# Altium schematic sheet Power.SchDoc
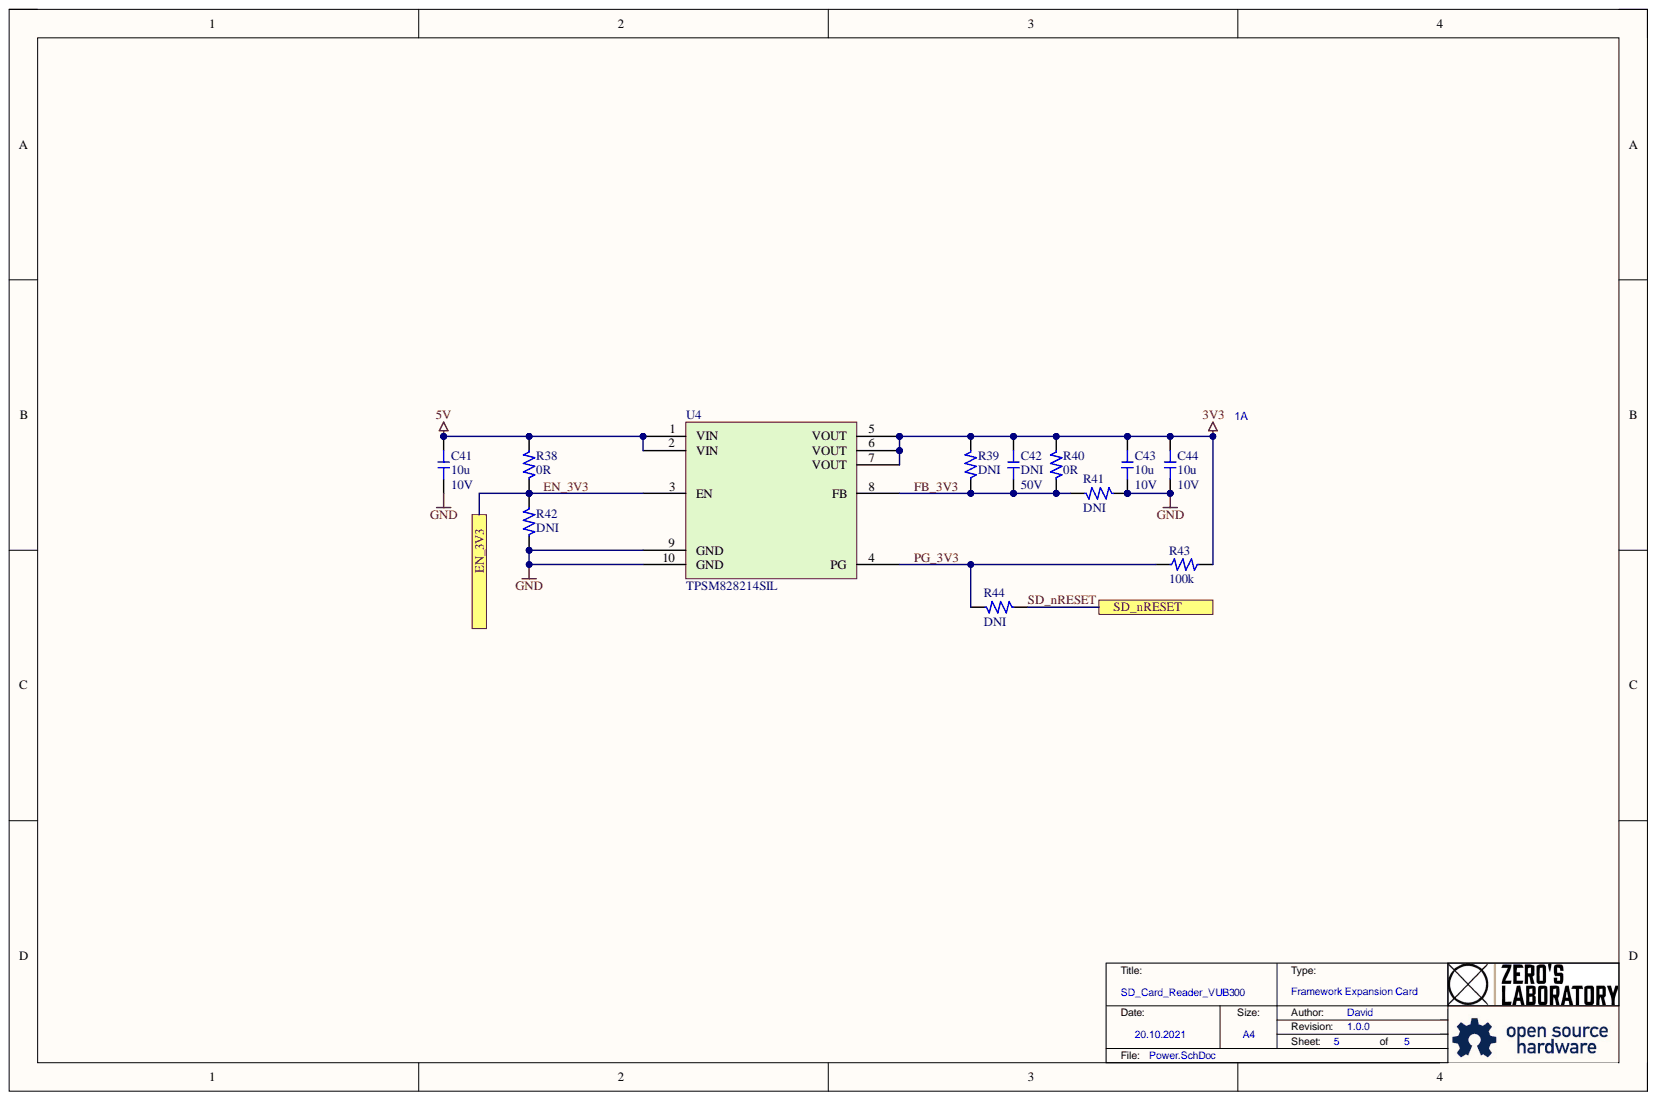
[diagram p0: Power.SchDoc]

## Parts
C41  10u  Voltage: 10V  [[1206]_CAPC320X160X160L50]
C42  DNI  Voltage: 50V  [[0402]_CAPC100X50X50L25]
C43  10u  Voltage: 10V  [[1206]_CAPC320X160X160L50]
C44  10u  Voltage: 10V  [[1206]_CAPC320X160X160L50]
R38  0R  [[0402]_RESC100X50X35L25]
R39  DNI  [[0402]_RESC100X50X35L25]
R40  0R  Voltage: 50V  [[0402]_RESC100X50X35L25]
R41  DNI  [[0402]_RESC100X50X35L25]
R42  DNI  [[0402]_RESC100X50X35L25]
R43  100k  [[0402]_RESC100X50X35L25]
R44  DNI  [[0402]_RESC100X50X35L25]
U4  TPSM828214SIL

## Ports
EN_3V3, SD_nRESET

## Nets
3V3: C42.2 C43.1 C44.1 R39.1 R40.1 R43.1 U4.5(VOUT) U4.6(VOUT) U4.7(VOUT)
5V: C41.1 R38.1 U4.1(VIN) U4.2(VIN)
GND: C41.2 C43.2 C44.2 R41.1 R42.2 U4.9(GND) U4.10(GND)
EN_3V3: R38.2 R42.1 U4.3(EN) ; port EN_3V3
FB_3V3: C42.1 R39.2 R40.2 R41.2 U4.8(FB)
PG_3V3: R43.2 R44.1 U4.4(PG)
SD_nRESET: R44.2 ; port SD_nRESET

## Library data of the parts
C41 C43 C44 = Capacitor: Manufacturer: CAL-CHIP ELECTRONICS; MPN: GMC31X7R106K10NT; Capacitance: 10uF; Dielectric: X7R; Tolerance: ±10%; Type: Ceramic; Component Kind: Standard; Description: Capacitor; Library Name: Capacitor.SchLib; Operating Temperature [°C]: -55 to +125; Package: 1206[3216]; Package Type: SMD; Size: 2.00mm x 1.25mm; Value: 10u
C42 = Capacitor: Capacitance: 120pF; Dielectric: X7R; Tolerance: ±10%; Type: Ceramic; Value: 120p; Component Kind: Standard; Description: Capacitor; Library Name: Capacitor.SchLib; Operating Temperature [°C]: -55 to +125; Package: 0402[1005]; Package Type: SMD; Size: 1.00mm x 0.50mm
R38 = Resistor: Rated Power: 62.5mW; Resistance: 0Ω; Tolerance: ±1%; Voltage: 50V; Component Kind: Standard; Description: Resistor; Library Name: Resistor.SchLib; Operating Temperature [°C]: -55 to +155; Package: 0402[1005]; Package Type: SMD; Size: 1.00mm x 0.50mm; Value: 0R
R39 = Resistor: Rated Power: 62.5mW; Resistance: 453kΩ; Tolerance: ±1%; Value: 453k; Voltage: 50V; Component Kind: Standard; Description: Resistor; Library Name: Resistor.SchLib; Operating Temperature [°C]: -55 to +155; Package: 0402[1005]; Package Type: SMD; Size: 1.00mm x 0.50mm
R40 = Resistor: Rated Power: 62.5mW; Resistance: 0Ω; Tolerance: ±1%; Component Kind: Standard; Description: Resistor; Library Name: Resistor.SchLib; Operating Temperature [°C]: -55 to +155; Package: 0402[1005]; Package Type: SMD; Size: 1.00mm x 0.50mm; Value: 0R
R41 = Resistor: Rated Power: 62.5mW; Resistance: 100kΩ; Tolerance: ±1%; Value: 100k; Voltage: 50V; Component Kind: Standard; Description: Resistor; Library Name: Resistor.SchLib; Operating Temperature [°C]: -55 to +155; Package: 0402[1005]; Package Type: SMD; Size: 1.00mm x 0.50mm
R42 R44 = Resistor: Rated Power: 62.5mW; Resistance: 0Ω; Tolerance: ±1%; Value: 0R; Voltage: 50V; Component Kind: Standard; Description: Resistor; Library Name: Resistor.SchLib; Operating Temperature [°C]: -55 to +155; Package: 0402[1005]; Package Type: SMD; Size: 1.00mm x 0.50mm
R43 = Resistor: Rated Power: 62.5mW; Resistance: 100kΩ; Tolerance: ±1%; Voltage: 50V; Component Kind: Standard; Description: Resistor; Library Name: Resistor.SchLib; Operating Temperature [°C]: -55 to +155; Package: 0402[1005]; Package Type: SMD; Size: 1.00mm x 0.50mm; Value: 100k
U4 = TPSM828214SIL: 1-A High Efficiency Step-Down Converter MicroSiP™ Power Module with Integrated Inductor; Manufacturer: Texas Instruments; Pitch: 0.50mm; Component Kind: Standard; Library Name: TexasInstruments.SchLib; MPN: TPSM828214SIL; MSL: Level-2-260C-1 YEAR; Name: TPSM8282; Operating temperature [°C]: -40 to +125; Package: uSIL-10; Package Type: SMD; Size: 2.00mm x 2.50mm; Type: Step-Down Converter Integrated Inductor; Value: TPSM828214SIL; Web: https://www.ti.com/product/TPS2521
